# Altium schematic sheet WIFI_ESP32.SchDoc(WIFI_ESP32)
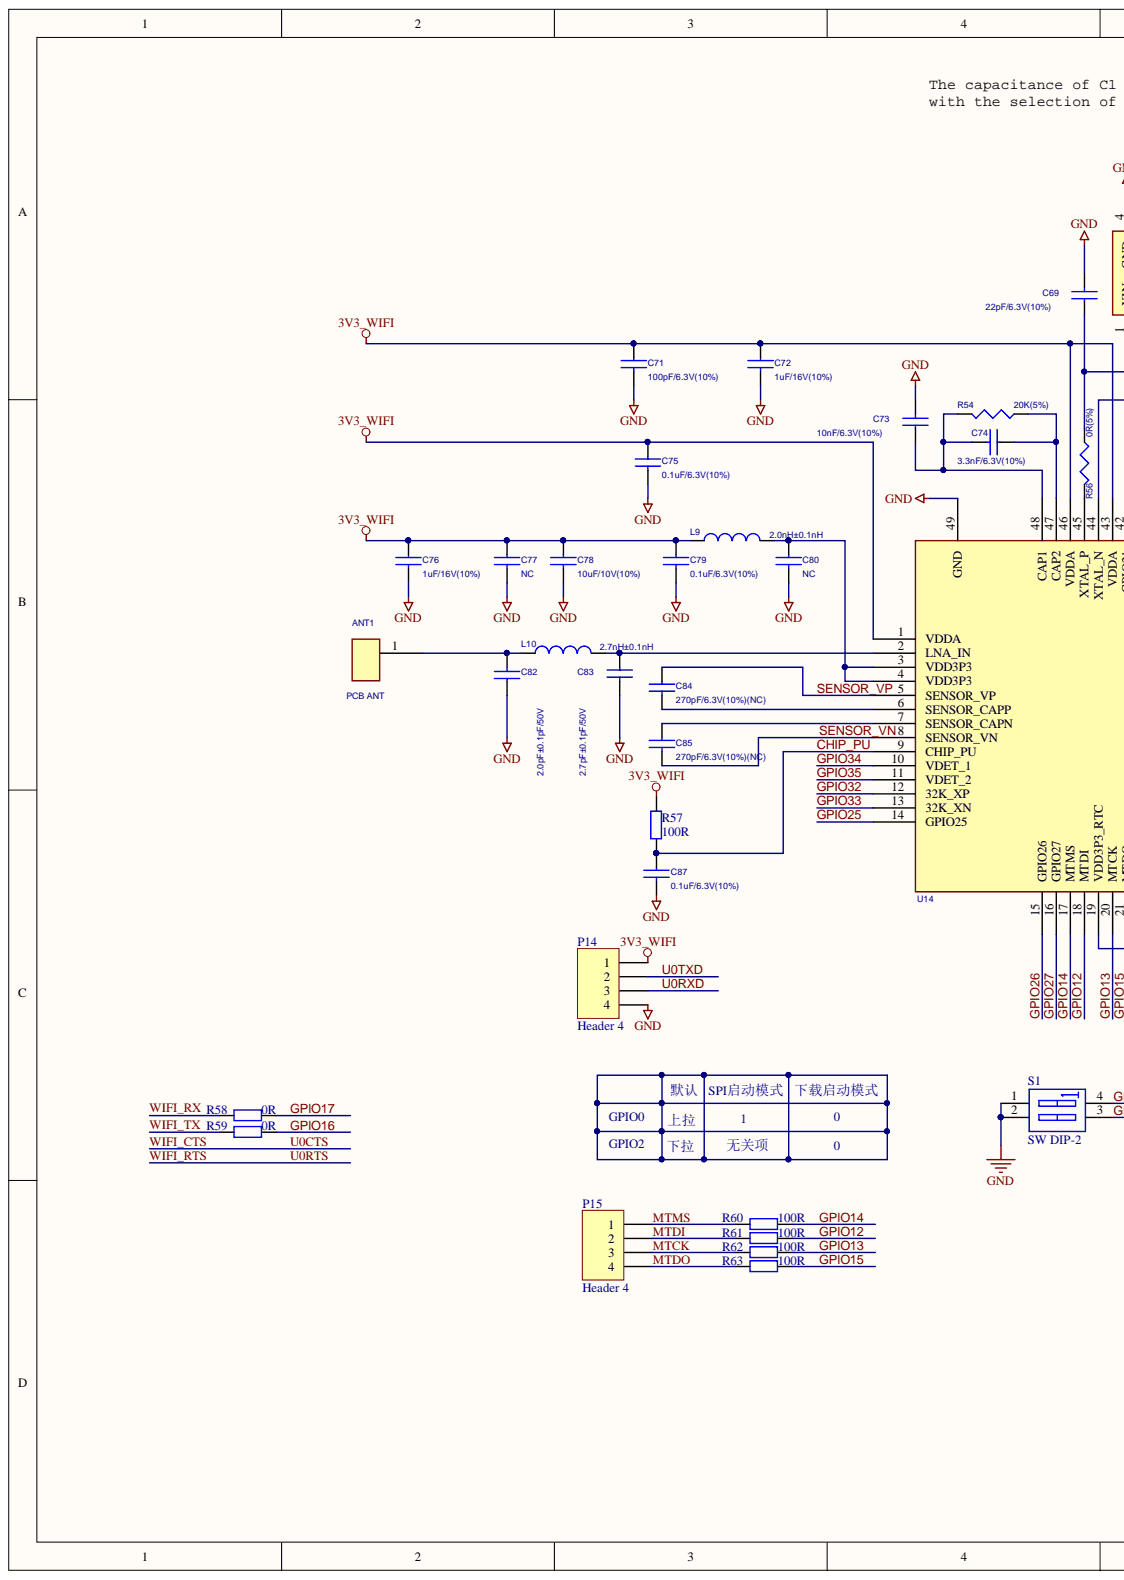
[diagram p0: WIFI_ESP32.SchDoc, left]
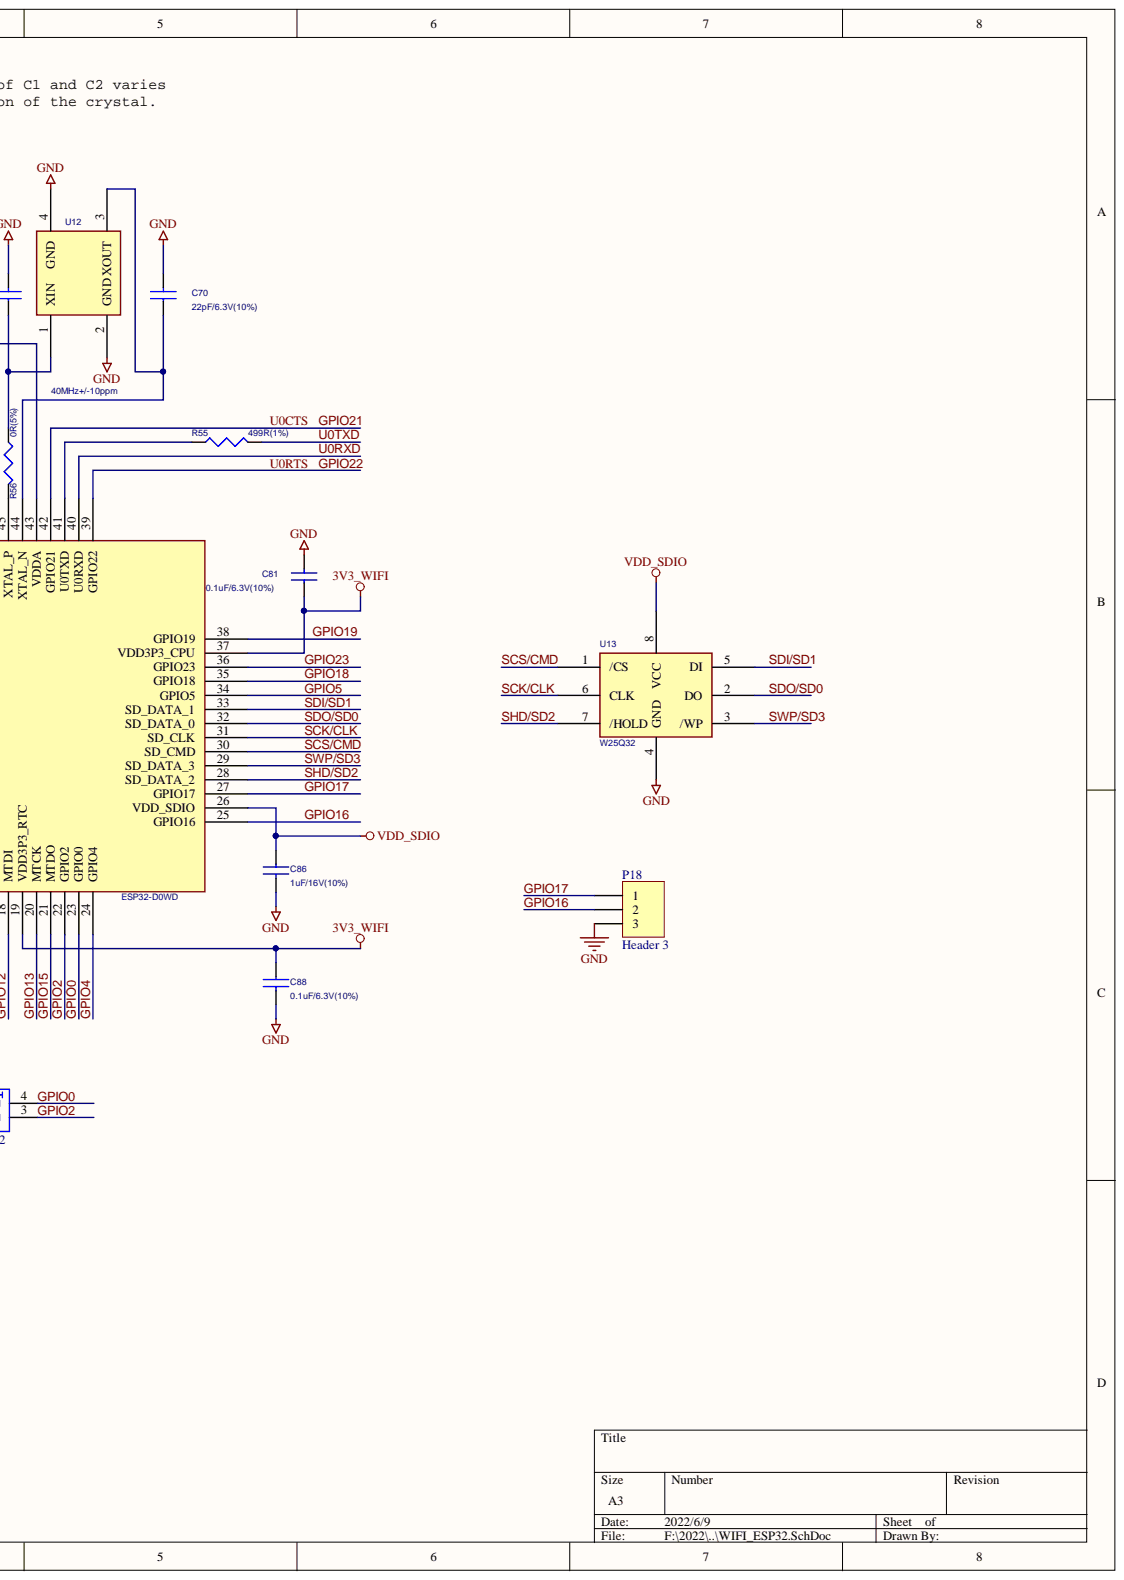
[diagram p1: WIFI_ESP32.SchDoc, right]

## Parts
ANT1  PCB ANT
C69  22pF/6.3V(10%)  [0402C]
C70  22pF/6.3V(10%)  [0402C]
C71  100pF/6.3V(10%)  [0402C]
C72  1uF/16V(10%)  [0402C]
C73  10nF/6.3V(10%)  [0402C]
C74  3.3nF/6.3V(10%)  [0402C]
C75  0.1uF/6.3V(10%)  [0402C]
C76  1uF/16V(10%)  [0402C]
C77  NC  [0402C]
C78  10uF/10V(10%)  [0402C]
C79  0.1uF/6.3V(10%)  [0402C]
C80  NC  [0402C]
C81  0.1uF/6.3V(10%)  [0402C]
C82  2.0pF±0.1pF/50V  [0402C]
C83  2.7pF±0.1pF/50V  [0402C]
C84  270pF/6.3V(10%)(NC)  [0402C]
C85  270pF/6.3V(10%)(NC)  [0402C]
C86  1uF/16V(10%)  [0402C]
C87  0.1uF/6.3V(10%)  [0402C]
C88  0.1uF/6.3V(10%)  [0402C]
L9  2.0nH±0.1nH  [0402L]
L10  2.7nH±0.1nH  [0402L]
P14  Header 4  [HDR1X4]
P15  Header 4  [HDR1X4]
P18  Header 3  [HDR1X3]
R54  20K(5%)  [0402R]
R55  499R(1%)  [0402R]
R56  0R(5%)  [0402R]
R57  100R  [R0603]
R58  0R  [R0603]
R59  0R  [R0603]
R60  100R  [R0603]
R61  100R  [R0603]
R62  100R  [R0603]
R63  100R  [R0603]
S1  SW DIP-2  [SW_1.27_2*2]
U12  40MHz+/-10ppm  [X_3225]
U13  W25Q32  [SOIC-8]
U14  ESP32-D0WD  [QFN48]

## Nets
3V3_WIFI: C71.1 C72.1 C75.1 C76.1 C77.1 C78.1 C79.1 C81.1 C88.1 L9.2 P14.1 R57.2 U14.1(VDDA) U14.19(VDD3P3_RTC) U14.37(VDD3P3_CPU) U14.43(VDDA) U14.46(VDDA)
GND: C69.2 C70.2 C71.2 C72.2 C73.2 C75.2 C76.2 C77.2 C78.2 C79.2 C80.2 C81.2 C82.1 C83.2 C86.2 C87.2 C88.2 P14.4 P18.3 S1.1 S1.2 U12.2(GND) U12.4(GND) U13.4(GND) U14.49(GND)
VDD_SDIO: C86.1 U13.8(VCC) U14.26(VDD_SDIO)
CHIP_PU: C87.1 R57.1 U14.9(CHIP_PU)
GPIO0: S1.4 U14.23(GPIO0)
GPIO2: S1.3 U14.22(GPIO2)
GPIO4: U14.24(GPIO4)
GPIO5: U14.34(GPIO5)
GPIO12: R61.2 U14.18(MTDI)
GPIO13: R62.2 U14.20(MTCK)
GPIO14: R60.2 U14.17(MTMS)
GPIO15: R63.2 U14.21(MTDO)
GPIO16: P18.2 R59.2 U14.25(GPIO16)
GPIO17: P18.1 R58.2 U14.27(GPIO17)
GPIO18: U14.35(GPIO18)
GPIO19: U14.38(GPIO19)
GPIO21: U14.42(GPIO21)
GPIO22: U14.39(GPIO22)
GPIO23: U14.36(GPIO23)
GPIO25: U14.14(GPIO25)
GPIO26: U14.15(GPIO26)
GPIO27: U14.16(GPIO27)
GPIO32: U14.12(32K_XP)
GPIO33: U14.13(32K_XN)
GPIO34: U14.10(VDET_1)
GPIO35: U14.11(VDET_2)
MTCK: P15.3 R62.1
MTDI: P15.2 R61.1
MTDO: P15.4 R63.1
MTMS: P15.1 R60.1
SCK/CLK: U13.6(CLK) U14.31(SD_CLK)
SCS/CMD: U13.1(/CS) U14.30(SD_CMD)
SDI/SD1: U13.5(DI) U14.33(SD_DATA_1)
SDO/SD0: U13.2(DO) U14.32(SD_DATA_0)
SENSOR_VP: C84.1 U14.5(SENSOR_VP)
SHD/SD2: U13.7(/HOLD) U14.28(SD_DATA_2)
SWP/SD3: U13.3(/WP) U14.29(SD_DATA_3)
U0RXD: P14.3 U14.40(U0RXD)
U0TXD: P14.2 R55.2
WIFI_RX: R58.1
WIFI_TX: R59.1
NetANT1_1: ANT1.1 C82.2 L10.2
NetC69_1: C69.1 R56.1 U12.1(XIN)
NetC70_1: C70.1 U12.3(XOUT) U14.44(XTAL_N)
NetC73_1: C73.1 C74.2 R54.2 U14.48(CAP1)
NetC74_1: C74.1 R54.1 U14.47(CAP2)
NetC80_1: C80.1 L9.1 U14.3(VDD3P3) U14.4(VDD3P3)
NetC83_1: C83.1 L10.1 U14.2(LNA_IN)
NetC84_2: C84.2 U14.6(SENSOR_CAPP)
NetC85_1: C85.1 U14.7(SENSOR_CAPN)
NetC85_2: C85.2 U14.8(SENSOR_VN)
NetR55_1: R55.1 U14.41(U0TXD)
NetR56_2: R56.2 U14.45(XTAL_P)
N00338:
SENSOR_VN:

## Library data of the parts
ANT1 = CON2: Component Kind: Standard
C69-C88 = CAP NP: Component Kind: Standard
L9 L10 = CHOKE: Component Kind: Standard
P14 P15 = Header 4: Header, 4-Pin; Component Kind: Standard; LatestRevisionDate: 17-Jul-2002; LatestRevisionNote: Re-released for DXP Platform.; Library Name: Miscellaneous Connectors.IntLib; Signal Integrity: Connector; Publisher: Altium Limited
P18 = Header 3: Header, 3-Pin; Component Kind: Standard; LatestRevisionDate: 17-Jul-2002; LatestRevisionNote: Re-released for DXP Platform.; Library Name: Miscellaneous Connectors.IntLib; Signal Integrity: Connector; Publisher: Altium Limited
R54-R56 = RESISTOR: Component Kind: Standard
R57-R63 = Res2: Resistor; Value: 1K; Component Kind: Standard; LatestRevisionDate: 17-Jul-2002; LatestRevisionNote: Re-released for DXP Platform.; Library Name: Miscellaneous Devices.IntLib; PackageDescription: Axial Device, Thru-Hole; 2 Leads; 0.4 in Pin Spacing; PackageReference: AXIAL-0.4; Published: 8-Jun-2000; Signal Integrity: Res; Simulation: RESISTOR; Publisher: Altium Limited
S1 = SW DIP-2: DIP Switch, 2 Position, SPST; Component Kind: Standard; LatestRevisionDate: 17-Jul-2002; LatestRevisionNote: Re-released for DXP Platform.; Library Name: Miscellaneous Devices.IntLib; PackageReference: DIP-4; Published: 24-Mar-1999; Simulation: dpsw2; Publisher: Altium Limited
U12 = X1_1: Component Kind: Standard
U13 = FLASH_3: Component Kind: Standard
U14 = ESP8080_24: Component Kind: Standard
